# Altium schematic sheet [03] - 28PINS SCHEMATIC.SchDoc("[03] - 28PINS SCHEMATIC") (continued)
## Library data of the parts (continued)
R10 R19 = RC0805FR-071ML: SMD Chip Resistor, 1 MOhm, ± 1%, 125 mW, 0805 [2012 Metric], Thick Film, General Purpose; Manufacturer: Yageo; Voltage Rating (AC): 150V; Temperature Coefficient: 250ppm/°C; Resistance: 1MR; Power Rating: 125mW; Voltage Rating: 150V; Tolerance: 1%; Supplier Part Number 1: 311-1.00MCRCT-ND; Supplier 1: Digikey; Stock 1: 525024; Size Code: 0805; RoHS Compliant: Yes; RoHS: Yes; Resistor Type: Thick Film; Resistance Tolerance: 1%; Reach SVHC Compliant: Unknown; Pricing 1: 1 = 0.1, 10 = 0.025, 50 = 0.016, 100 = 0.0133, 500 = 0.00912, 1000 = 0.00787 (USD); Packaging: Tape and Reel; Min Operating Temperature: -55°C; Military Standard: Not; Max Operating Temperature: 155°C; Manufacturer Part Number: RC0805FR-071ML; Library Name: 28Pins.SchLib; Length: 2mm; Lead Free: Lead Free; Depth: 1.25mm; Construction: Rectangular; ComponentLink4URL: https://datasheet.ciiva.com/2519/pyu-r-int-thick-7-2519713.pdf?src-supplier=Digikey; ComponentLink4Description: https://datasheet.ciiva.com/2519/pyu-r-int-thick-7-2519713.pdf?src-supplier=Digikey; ComponentLink3URL: https://datasheet.ciiva.com/2519/pyu-r-int-thick-7-2519712.pdf?src-supplier=Digikey; ComponentLink3Description: https://datasheet.ciiva.com/2519/pyu-r-int-thick-7-2519712.pdf?src-supplier=Digikey; ComponentLink2URL: https://datasheet.ciiva.com/2519/pyu-r-int-thick-7-2519711.pdf?src-supplier=Digikey; ComponentLink2Description: https://datasheet.ciiva.com/2519/pyu-r-int-thick-7-2519711.pdf?src-supplier=Digikey; ComponentLink1URL: https://datasheet.ciiva.com/12666/rc0805-12666975.pdf?src-supplier=Mouser; ComponentLink1Description: https://datasheet.ciiva.com/12666/rc0805-12666975.pdf?src-supplier=Mouser; Component Kind: Standard; Case Code (Metric): 2012; Case Code (Imperial): 0805; Case/Package: Molded
R11-R13 = RC0805FR-071KL: SMD Chip Resistor, 1 kOhm, ± 1%, 125 mW, 0805 [2012 Metric], Thick Film, General Purpose; Manufacturer: Yageo; Voltage Rating (DC): 150V; Temperature Coefficient: 100ppm/°C; Resistance: 1kR; Power Rating: 125mW; Width: 0.049inch; Voltage Rating (AC): 150V; Voltage Rating: 150V; Tolerance: 1%; Termination: SMD/SMT; Supplier Part Number 1: 311-1.00KCRCT-ND; Supplier 1: Digikey; Stock 1: 4393675; Size Code: 0805; Series: RC; RoHS Compliant: Yes; RoHS: Yes; Resistor Type: Thick Film; Resistance Tolerance: 1%; Reach SVHC Compliant: No; Pricing 1: 1 = 0.1, 10 = 0.025, 50 = 0.016, 100 = 0.0133, 500 = 0.00912, 1000 = 0.00787 (USD); Packaging: Tape and Reel; Package Quantity: 1; Number of Terminations: 2; Number of Pins: 2; Min Operating Temperature: -55°C; Military Standard: Not; Max Power Dissipation: 125mW; Max Operating Temperature: 155°C; Manufacturer Part Number: RC0805FR-071KL; Library Name: 28Pins.SchLib; Length: 0.079inch; Lead Free: 5697LeadFree; Height: 0.024inch; Features: Moisture Resistant; Depth: 1.25mm; Construction: Rectangular; ComponentLink6URL: https://datasheet.ciiva.com/16850/rc0805-16850409.pdf?src-supplier=Future+Electronics; ComponentLink6Description: https://datasheet.ciiva.com/16850/rc0805-16850409.pdf?src-supplier=Future+Electronics; ComponentLink5URL: https://datasheet.ciiva.com/2456/pyu-r-int-thick-7-2456975.pdf?src-supplier=Digikey; ComponentLink5Description: https://datasheet.ciiva.com/2456/pyu-r-int-thick-7-2456975.pdf?src-supplier=Digikey; ComponentLink4URL: https://datasheet.ciiva.com/2456/pyu-r-int-thick-7-2456977.pdf?src-supplier=Digikey; ComponentLink4Description: https://datasheet.ciiva.com/2456/pyu-r-int-thick-7-2456977.pdf?src-supplier=Digikey; ComponentLink3URL: https://datasheet.ciiva.com/2456/pyu-r-int-thick-7-2456976.pdf?src-supplier=Digikey; ComponentLink3Description: https://datasheet.ciiva.com/2456/pyu-r-int-thick-7-2456976.pdf?src-supplier=Digikey; ComponentLink2URL: https://datasheet.ciiva.com/46385/444164-46385020.pdf?src-supplier=Element14; ComponentLink2Description: https://datasheet.ciiva.com/46385/444164-46385020.pdf?src-supplier=Element14; ComponentLink1URL: https://datasheet.ciiva.com/7941/1686128-7941719.pdf?src-supplier=Element14; ComponentLink1Description: https://datasheet.ciiva.com/7941/1686128-7941719.pdf?src-supplier=Element14; Component Kind: Standard; Case Code (Metric): 2012; Case Code (Imperial): 0805; Case/Package: 0805
SCKT_FOR_U1 = 4828-3004-CP: Socket DIP 28Cnts DualWipe 0.3InSpc. PhosphorBronze Nickel KinkedTail 4800Series; Manufacturer: 3M; Row Spacing: 7.62mm; Pitch: 2.54mm; Number of Positions: 28; Insulation Resistance: 5GR; Gender: Female; Current Rating: 1A; Width: 10.16mm; Termination: Solder; Supplier Part Number 1: 3M5480-ND; Supplier 1: Digikey; Stock 1: 12659; Series: 4800; RoHS Compliant: Yes; RoHS: Yes; Reach SVHC Compliant: Unknown; Pricing 1: 1 = 1.06, 10 = 0.896, 25 = 0.8588, 50 = 0.8406, 100 = 0.8041, 250 = 0.73104, 500 = 0.65794, 1000 = 0.58483, 2500 = 0.53 (USD); Packaging: Rail/Tube; Package Quantity: 5600; Orientation: Straight; Number of Rows: 2; Number of Contacts: 28; Mount: Through Hole; Min Operating Temperature: -25°C; Max Operating Temperature: 85°C; Manufacturer Part Number: 4828-3004-CP; Library Name: 28Pins.SchLib; Length: 35.43mm; Lead Free: Lead Free; Insulation Material: Polyester; Housing Material: Glass; Flammability Rating: UL94 V-0; Depth: 10.16mm; Contact Plating: Tin; Contact Material: Bronze; Contact Finish - Mating: TIN; ComponentLink1URL: https://datasheet.ciiva.com/768/ts1099-768354.pdf?src-supplier=Digikey; ComponentLink1Description: https://datasheet.ciiva.com/768/ts1099-768354.pdf?src-supplier=Digikey; Component Kind: Standard
SW1 SW2 = 1825910-6: Alcoswitch, Switch, Pushbutton, Tactile, SPST, 50mA, Thru Hole, 6mm; Manufacturer: TE Connectivity Alcoswitch; Switch Travel: 0.25mm; Switch Function: Off-Mom; Operating Force: 160gf; Number of Positions: 2NUMBER; Mechanical Life: 100000Cycles; Max Voltage Rating (DC): 24V; Insulation Resistance: 100MR; Illumination Type: Non-Illuminated,Illuminated; Actuator Type: Standard; Actuator Material: PBT; Actuator Length: 0.055inch; Width: 6mm; Type: Switch; Throw Configuration: SPST; Termination: PC Pins; Supplier Part Number 1: 450-1650-ND; Supplier 1: Digikey; Stock 1: 90921; Series: FSMJ; RoHS Compliant: Yes; RoHS: Yes; Reach SVHC Compliant: Unknown; Pricing 1: 1 = 0.13, 10 = 0.125, 25 = 0.1184, 100 = 0.1078, 250 = 0.10132, 500 = 0.09268, 1000 = 0.07759, 2500 = 0.07544, 5000 = 0.07113 (USD); Packaging: Loose Piece; Mount: Through Hole; Min Operating Temperature: -35°C; Max Operating Temperature: 85°C; Max Current Rating: 50mA; Material: 4261ConductorMaterial; Manufacturer Part Number: 1825910-6; Library Name: 28Pins.SchLib; Length: 5.99mm; Lead Free: Lead Free; ELV: Compliant; Current Rating: 50mA; Contact Plating: Silver; Contact Material: Copper Alloy; Contact Current Rating: 50mA; Component Kind: Standard; Color: 46Colour
U1 = ATMEGA16U2-AU: Risc Microcontroller, 8-BIT, Flash, AVR Risc Cpu, 16MHZ, Cmos, PQFP32; Manufacturer: Microchip; RAM Size: 512B; Peripherals: Brown-out Detect/Reset,POR,PWM,WDT; Oscillator Type: Internal; Number of Timers/Counters: 2; Number of SPI Channels: 1; Number of PWM Channels: 5; Number of I/Os: 22; Min Supply Voltage: 2.7V; Memory Size: 16KB; Max Supply Voltage: 5.5V; Interface: SPI,UART,USB,USART,Serial; Data Bus Width: 8b; Width: 7mm; Weight: 0.002568oz; Supplier Part Number 1: ATMEGA16U2-AU-ND; Supplier 1: Digikey; Stock 1: 32268; Series: ATmega16U2; RoHS Compliant: Yes; RoHS: Yes; Reach SVHC Compliant: No; Pricing 1: 1 = 3.24, 25 = 2.97, 100 = 2.7 (USD); Packaging: Tray; Package Quantity: 250; Number of Pins: 32; Mount: Surface Mount; Min Operating Temperature: -40°C; Memory Type: FLASH; Max Operating Temperature: 85°C; Max Frequency: 16MHz; Manufacturer Part Number: ATMEGA16U2-AU; Library Name: 28Pins.SchLib; Length: 7mm; Lead Free: Lead Free; Height: 1mm; Frequency: 16MHz; Core Architecture: AVR; ComponentLink2URL: https://datasheet.ciiva.com/22651/doc7799-22651110.pdf?src-supplier=Farnell; ComponentLink2Description: https://datasheet.ciiva.com/22651/doc7799-22651110.pdf?src-supplier=Farnell; ComponentLink1URL: https://datasheet.ciiva.com/13036/doc7799-28769-13036800.pdf?src-supplier=Mouser; ComponentLink1Description: https://datasheet.ciiva.com/13036/doc7799-28769-13036800.pdf?src-supplier=Mouser; Component Kind: Standard; Case/Package: A
U2 = TL1963A-33DCQR: LDO Regulator Pos 3.3V 1.5A 6-Pin(5+Tab) SOT-223 T/R; Manufacturer: Texas Instruments; Quiescent Current: 1mA; Polarity: Positive; Output Type: Fixed; Number of Regulators: 1; Min Input Voltage: 2.1V; Min Current Limit: 1.6A; Max Input Voltage: 20V; Dropout Voltage: 340mV; Accuracy: 3%; Supplier Part Number 1: 296-24534-1-ND; Supplier 1: Digikey; Stock 1: 9432; Series: -; RoHS Compliant: Yes; RoHS: Yes; Radiation Hardening: No; Pricing 1: 1 = 3.45, 10 = 3.098, 25 = 2.9292, 100 = 2.5387, 250 = 2.40848, 500 = 2.16114, 1000 = 1.82265 (USD); Packaging: Tape and Reel; Package Quantity: 1; Output Voltage: 3.3V; Output Current: 1.5A; Number of Pins: 6; Number of Outputs: 1; Min Output Voltage: 3.3V; Min Operating Temperature: -40°C; Max Output Voltage: 3.3V; Max Output Current: 1.5A; Max Operating Temperature: 125°C; Manufacturer Part Number: TL1963A-33DCQR; Library Name: 28Pins.SchLib; Lead Free: Lead Free; Input Bias Current: 1mA; ComponentLink3URL: https://datasheet.ciiva.com/2046/tl1963a-2046163.pdf?src-supplier=Digikey; ComponentLink3Description: https://datasheet.ciiva.com/2046/tl1963a-2046163.pdf?src-supplier=Digikey; ComponentLink2URL: https://datasheet.ciiva.com/2046/tl1963a-2046161.pdf?src-supplier=Digikey; ComponentLink2Description: https://datasheet.ciiva.com/2046/tl1963a-2046161.pdf?src-supplier=Digikey; ComponentLink1URL: https://datasheet.ciiva.com/2046/tl1963a-2046162.pdf?src-supplier=Digikey; ComponentLink1Description: https://datasheet.ciiva.com/2046/tl1963a-2046162.pdf?src-supplier=Digikey; Component Kind: Standard; Case/Package: SOT-223-6
U3 = ATMEGA328P-PU: Microchip - ATMEGA328P-PU - Microcontroller Mcu, 8 Bit, Atmega, 20MHZ, DIP-28; RAM Size: 2KB; Peripherals: Brown-out Detect/Reset,POR,PWM,WDT; Oscillator Type: Internal; Number of Timers/Counters: 3; Number of SPI Channels: 1; Number of PWM Channels: 6; Number of I/Os: 23; Min Supply Voltage: 1.8V; Memory Type: FLASH; Memory Size: 32KB; Max Supply Voltage: 5.5V; Interface: I2C,SPI,USART,UART,2-Wire; Data Bus Width: 8b; Core Architecture: AVR; Width: 7.49mm; Weight: 0.077603oz; Supplier Part Number 1: ATMEGA328P-PU-ND; Supplier 1: Digikey; Stock 1: 98727; Series: ATmega328P; RoHS Compliant: Yes; RoHS: Yes; Reach SVHC Compliant: No; Radiation Hardening: No; Pricing 1: 1 = 2.89, 25 = 2.65, 100 = 2.39 (USD); Packaging: Rail/Tube; Package Quantity: 14; Number of Pins: 28; Number of I2C Channels: 1; Number of ADC Channels: 6; Mount: Through Hole; Min Operating Temperature: -40°C; Max Operating Temperature: 85°C; Max Frequency: 20MHz; Manufacturer Part Number: ATMEGA328P-PU; Manufacturer: Microchip; Library Name: 28Pins.SchLib; Length: 34.8mm; Lead Free: 5697LeadFree; Height: 4.57mm; Frequency: 20MHz; ComponentLink4URL: https://datasheet.ciiva.com/15213/getdatasheetpartid-318533-15213133.pdf?src-supplier=Verical; ComponentLink4Description: https://datasheet.ciiva.com/15213/getdatasheetpartid-318533-15213133.pdf?src-supplier=Verical; ComponentLink3URL: https://datasheet.ciiva.com/29119/155197-da-01-en-microcontr-atmega328p-pu-8bit-pdip-28-29119593.pdf?src-supplier=Conrad; ComponentLink3Description: https://datasheet.ciiva.com/29119/155197-da-01-en-microcontr-atmega328p-pu-8bit-pdip-28-29119593.pdf?src-supplier=Conrad; ComponentLink2URL: https://datasheet.ciiva.com/1157/1684409-1157696.pdf?src-supplier=Element14; ComponentLink2Description: https://datasheet.ciiva.com/1157/1684409-1157696.pdf?src-supplier=Element14; ComponentLink1URL: https://datasheet.ciiva.com/19457/2139111-19457070.pdf?src-supplier=Jameco; ComponentLink1Description: https://datasheet.ciiva.com/19457/2139111-19457070.pdf?src-supplier=Jameco; Component Kind: Standard; Case/Package: PDIP
U4-U7 = SN74AHC1G09DBVR: 1-ch, 2-input 2-V to 5.5-V high-speed (9 ns) AND gate with open-drain outputs 5-SOT-23 -55 to 125; Manufacturer: Texas Instruments; Quiescent Current: 1uA; Propagation Delay: 7.5ns; Output Voltage: 5.5V; Output Current: 25mA; Number of Outputs: 1; Number of Inputs: 2; Number of Channels: 1NUMBER; Min Supply Voltage: 2V; Low Level Output Current: 8mA; Width: 1.6mm; Thickness: 1.2mm; Technology: CMOS; Supplier Part Number 1: 296-29202-1-ND; Supplier 1: Digikey; Stock 1: 16049; Series: 74AHC; RoHS Compliant: Yes; RoHS: Yes; Reach SVHC Compliant: No; Radiation Hardening: No; Pricing 1: 1 = 0.36, 10 = 0.269, 25 = 0.2352, 100 = 0.1522, 250 = 0.126, 500 = 0.1008, 1000 = 0.07729 (USD); Packaging: Tape and Reel; Package Quantity: 3000; Number of Pins: 5; Number of Gates: 1; Number of Elements: 1; Number of Circuits: 1; Number of Bits: 1; Nominal Supply Current: 1uA; Mount: Surface Mount; Min Operating Temperature: -55°C; Max Supply Voltage: 5.5V; Max Operating Temperature: 125°C; Manufacturer Part Number: SN74AHC1G09DBVR; Logic Function: AND GATE; Library Name: 28Pins.SchLib; Length: 2.9mm; Lead Free: Lead Free; Height: 1.45mm; ComponentLink3URL: https://datasheet.ciiva.com/1864/sn74ahc1g09-1864412.pdf?src-supplier=Digikey; ComponentLink3Description: https://datasheet.ciiva.com/1864/sn74ahc1g09-1864412.pdf?src-supplier=Digikey; ComponentLink2URL: https://datasheet.ciiva.com/1864/sn74ahc1g09-1864410.pdf?src-supplier=Digikey; ComponentLink2Description: https://datasheet.ciiva.com/1864/sn74ahc1g09-1864410.pdf?src-supplier=Digikey; ComponentLink1URL: https://datasheet.ciiva.com/1864/sn74ahc1g09-1864411.pdf?src-supplier=Digikey; ComponentLink1Description: https://datasheet.ciiva.com/1864/sn74ahc1g09-1864411.pdf?src-supplier=Digikey; Component Kind: Standard; Case/Package: SOT-23
X1 X2 = CG0603MLC-05E: ESD Suppressor Multilayer Varistor 5V Automotive 2-Pin Case 0603(1608Metric) T/R; Manufacturer: Bourns; Voltage Rating (AC): 8V; Number of Circuits: 1; Leakage Current: 50nA; Clamping Voltage: 20V; Capacitance: 0.5pF; Working Voltage: 5V; Width: 0.8mm; Voltage Rating (DC): 5V; Voltage: 5V; Thickness: 0.8mm; Termination: SMD/SMT; Supplier Part Number 1: CG0603MLC-05ECT-ND; Supplier 1: Digikey; Stock 1: 184877; Series: MLC; RoHS Compliant: Yes; RoHS: Yes; Reach SVHC Compliant: No; Radiation Hardening: No; Pricing 1: 1 = 0.45, 10 = 0.336, 25 = 0.3032, 100 = 0.2096, 250 = 0.17648, 500 = 0.14338, 1000 = 0.11029, 2500 = 0.09926 (USD); Packaging: Tape and Reel; Package Quantity: 1; Operating Supply Voltage: 5V; Number of Pins: 2; Number of Channels: 1; Min Operating Temperature: -40°C; Max Voltage Rating (DC): 5V; Max Operating Temperature: 85°C; Manufacturer Part Number: CG0603MLC-05E; Library Name: 28Pins.SchLib; Length: 1.6mm; Depth: 0.8mm; Current Rating: 50nA; Contact Plating: Tin; ComponentLink5URL: https://datasheet.ciiva.com/6991/mlc-6991832.pdf?src-supplier=Digikey; ComponentLink5Description: https://datasheet.ciiva.com/6991/mlc-6991832.pdf?src-supplier=Digikey; ComponentLink4URL: https://datasheet.ciiva.com/6991/mlc-6991836.pdf?src-supplier=Digikey; ComponentLink4Description: https://datasheet.ciiva.com/6991/mlc-6991836.pdf?src-supplier=Digikey; ComponentLink3URL: https://datasheet.ciiva.com/8084/mlc-8084047.pdf?src-supplier=Avnet; ComponentLink3Description: https://datasheet.ciiva.com/8084/mlc-8084047.pdf?src-supplier=Avnet; ComponentLink2URL: https://datasheet.ciiva.com/22696/1785244-22696318.pdf?src-supplier=Element14; ComponentLink2Description: https://datasheet.ciiva.com/22696/1785244-22696318.pdf?src-supplier=Element14; ComponentLink1URL: https://datasheet.ciiva.com/1278/1785244-1278701.pdf?src-supplier=Element14; ComponentLink1Description: https://datasheet.ciiva.com/1278/1785244-1278701.pdf?src-supplier=Element14; Component Kind: Standard; Case Code (Metric): 1608; Case Code (Imperial): 0603; Case/Package: 0603
XTAL1 = ABM7-8.000MHZ-D2Y-T: Crystal 8MHz ±20ppm (Tol) ±30ppm (Stability) 18pF FUND 100Ohm 2-Pin Mini-CSMD T/R; Manufacturer: Abracon; Series Resistance: 80R; ESR (Equivalent Series Resistance): 100R; Width: 3.3mm; Tolerance: 20ppm; Termination: SMD/SMT; Supplier Part Number 1: 535-9831-1-ND; Supplier 1: Digikey; Stock 1: 35427; Series: ABM7; RoHS: Yes; Reach SVHC Compliant: Yes; Radiation Hardening: No; Pricing 1: 1 = 0.67, 10 = 0.556, 50 = 0.5, 100 = 0.4444, 500 = 0.42218 (USD); Packaging: Tape and Reel; Package Quantity: 1000; Operating Frequency: 8 MHz; Number of Pins: 2; Mount: Surface Mount; Min Operating Temperature: -40°C; Max Operating Temperature: 85°C; Manufacturer Part Number: ABM7-8.000MHZ-D2Y-T; Load Capacitance: 18pF; Library Name: 28Pins.SchLib; Length: 6mm; Lead Free: 5697LeadFree; Height: 1.4mm; Frequency Tolerance: 20ppm; Frequency Stability: 30ppm; Frequency: 8MHz; Depth: 3.5mm; ComponentLink5URL: https://datasheet.ciiva.com/4944/abm7-19096-4944987.pdf?src-supplier=Mouser; ComponentLink5Description: https://datasheet.ciiva.com/4944/abm7-19096-4944987.pdf?src-supplier=Mouser; ComponentLink4URL: https://datasheet.ciiva.com/16416/abm7-16416924.pdf?src-supplier=Future+Electronics; ComponentLink4Description: https://datasheet.ciiva.com/16416/abm7-16416924.pdf?src-supplier=Future+Electronics; ComponentLink3URL: https://datasheet.ciiva.com/29998/abm7-29998171.pdf?src-supplier=Avnet; ComponentLink3Description: https://datasheet.ciiva.com/29998/abm7-29998171.pdf?src-supplier=Avnet; ComponentLink2URL: https://datasheet.ciiva.com/15116/getdatasheetpartid-722403-15116844.pdf?src-supplier=Verical; ComponentLink2Description: https://datasheet.ciiva.com/15116/getdatasheetpartid-722403-15116844.pdf?src-supplier=Verical; ComponentLink1URL: https://datasheet.ciiva.com/1357/1718755-1357277.pdf?src-supplier=Element14; ComponentLink1Description: https://datasheet.ciiva.com/1357/1718755-1357277.pdf?src-supplier=Element14; Component Kind: Standard; Case/Package: CSMD
XTAL1 XTAL2 = ABM7-16.000MHZ-D2Y-T: Crystal 16MHz ±20ppm (Tol) ±30ppm (Stability) 18pF FUND 50Ohm 2-Pin Mini-CSMD T/R; Manufacturer: Abracon; ESR (Equivalent Series Resistance): 50R; Width: 3.3mm; Tolerance: 20ppm; Termination: SMD/SMT; Supplier Part Number 1: 535-9840-1-ND; Supplier 1: Digikey; Stock 1: 69328; Series: ABM7; RoHS: Yes; Reach SVHC Compliant: Unknown; Pricing 1: 1 = 0.67, 10 = 0.556, 50 = 0.5, 100 = 0.4444, 500 = 0.42218 (USD); Packaging: Tape and Reel; Package Quantity: 1000; Operating Frequency: 16 MHz; Number of Pins: 2; Mount: Surface Mount; Min Operating Temperature: -40°C; Max Operating Temperature: 85°C; Manufacturer Part Number: ABM7-16.000MHZ-D2Y-T; Load Capacitance: 18pF; Library Name: 28Pins.SchLib; Length: 6mm; Lead Free: Lead Free; Height: 1.4mm; Frequency Tolerance: 20ppm; Frequency Stability: 30ppm; Frequency: 16MHz; Depth: 3.5mm; ComponentLink10URL: https://datasheet.ciiva.com/26941/getdatasheetpartid-719818-26941724.pdf?src-supplier=Verical; ComponentLink10Description: https://datasheet.ciiva.com/26941/getdatasheetpartid-719818-26941724.pdf?src-supplier=Verical; ComponentLink9URL: https://datasheet.ciiva.com/46385/947971-46385243.pdf?src-supplier=Element14; ComponentLink9Description: https://datasheet.ciiva.com/46385/947971-46385243.pdf?src-supplier=Element14; ComponentLink8URL: https://datasheet.ciiva.com/22658/947971-22658293.pdf?src-supplier=Element14; ComponentLink8Description: https://datasheet.ciiva.com/22658/947971-22658293.pdf?src-supplier=Element14; ComponentLink7URL: https://datasheet.ciiva.com/7998/947971-7998600.pdf?src-supplier=Element14; ComponentLink7Description: https://datasheet.ciiva.com/7998/947971-7998600.pdf?src-supplier=Element14; ComponentLink6URL: https://datasheet.ciiva.com/16383/abm7-16383174.pdf?src-supplier=Future+Electronics; ComponentLink6Description: https://datasheet.ciiva.com/16383/abm7-16383174.pdf?src-supplier=Future+Electronics; ComponentLink5URL: https://datasheet.ciiva.com/5788/abm7-5788054.pdf?src-supplier=Farnell; ComponentLink5Description: https://datasheet.ciiva.com/5788/abm7-5788054.pdf?src-supplier=Farnell; ComponentLink4URL: https://datasheet.ciiva.com/18649/abm7-18649867.pdf?src-supplier=Digikey; ComponentLink4Description: https://datasheet.ciiva.com/18649/abm7-18649867.pdf?src-supplier=Digikey; ComponentLink3URL: https://datasheet.ciiva.com/18649/abm7-18649866.pdf?src-supplier=Digikey; ComponentLink3Description: https://datasheet.ciiva.com/18649/abm7-18649866.pdf?src-supplier=Digikey; ComponentLink2URL: https://datasheet.ciiva.com/18649/abm7-18649865.pdf?src-supplier=Digikey; ComponentLink2Description: https://datasheet.ciiva.com/18649/abm7-18649865.pdf?src-supplier=Digikey; ComponentLink1URL: https://datasheet.ciiva.com/15116/getdatasheetpartid-721624-15116835.pdf?src-supplier=Verical; ComponentLink1Description: https://datasheet.ciiva.com/15116/getdatasheetpartid-721624-15116835.pdf?src-supplier=Verical; Component Kind: Standard; Case/Package: CSMD
XTAL2 = ABM7-10.000MHZ-D2Y-T: Crystal 10MHz ±20ppm (Tol) ±30ppm (Stability) 18pF FUND 80Ohm 2-Pin Mini-CSMD T/R; Manufacturer: Abracon; Tolerance: 0.002%; Series Resistance: 60R; Width: 3.5mm; Supplier Part Number 1: 535-9833-6-ND; Supplier 1: Digikey; Stock 1: 0; Series: ABM7; RoHS: Yes; Pricing 1: 1 = 0.66, 10 = 0.581, 50 = 0.5428, 100 = 0.4798, 500 = 0.4545 (USD); Packaging: Cut Tape; Operating Frequency: 10 MHz; Mount: Surface Mount; Min Operating Temperature: -40°C; Max Operating Temperature: 85°C; Manufacturer Part Number: ABM7-10.000MHZ-D2Y-T; Load Capacitance: 18pF; Library Name: 28Pins.SchLib; Length: 6mm; Lead Free: Lead Free; Height: 1.4mm; Frequency Tolerance: 20ppm; Frequency Stability: 30ppm; Frequency: 10MHz; ESR (Equivalent Series Resistance): 60R; ComponentLink1URL: https://datasheet.ciiva.com/16397/abm7-16397372.pdf?src-supplier=Future+Electronics; ComponentLink1Description: https://datasheet.ciiva.com/16397/abm7-16397372.pdf?src-supplier=Future+Electronics; Component Kind: Standard; Case/Package: SMD/SMT
